# Altium schematic sheet RoBeast_Power_Linear.SchDoc(RoBeast_Power_Linear)
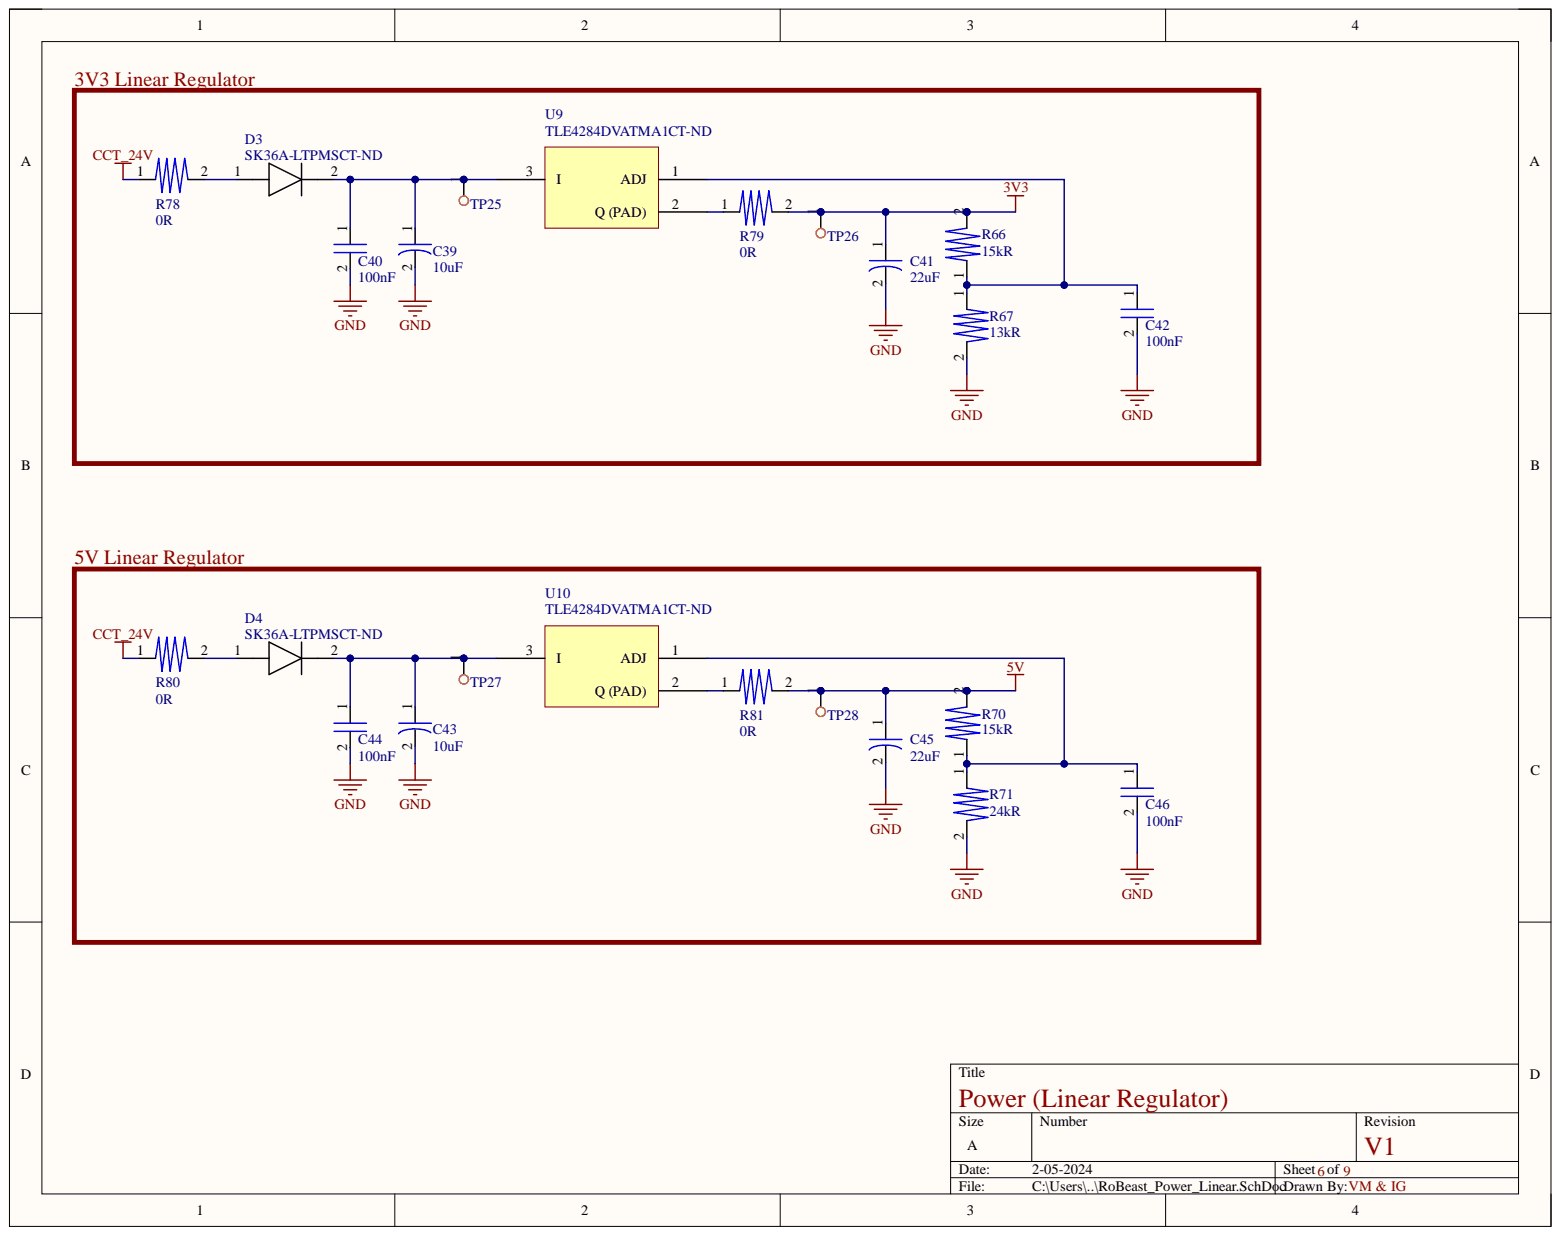
[diagram p0: RoBeast_Power_Linear.SchDoc]

## Parts
C39  10uF  [EXV106M050A9GAA]
C40  100nF  [0805]
C41  22uF  [EEV226M050A9GAA]
C42  100nF  [0805]
C43  10uF  [EXV106M050A9GAA]
C44  100nF  [0805]
C45  22uF  [EEV226M050A9GAA]
C46  100nF  [0805]
D3  Supplier Part Number 1: SK36A-LTPMSCT-ND  [SK36A-LTP]  (hidden comment: Shottky Diode)
D4  Supplier Part Number 1: SK36A-LTPMSCT-ND  [SK36A-LTP]  (hidden comment: Shottky Diode)
R66  15kR  [0805]
R67  13kR  [0805]
R70  15kR  [0805]
R71  24kR  [0805]
R78  0R  [1210]
R79  0R  [1210]
R80  0R  [1210]
R81  0R  [1210]
TP25  Test Point  [Test Point]  (value not drawn)
TP26  Test Point  [Test Point]  (value not drawn)
TP27  Test Point  [Test Point]  (value not drawn)
TP28  Test Point  [Test Point]  (value not drawn)
U9  Linear Regulator  Supplier Part Number 1: TLE4284DVATMA1CT-ND  [TLE4284DVATMA1]
U10  Linear Regulator  Supplier Part Number 1: TLE4284DVATMA1CT-ND  [TLE4284DVATMA1]

## Nets
3V3: C41.1 R66.2 R79.2 TP26.1
5V: C45.1 R70.2 R81.2 TP28.1
CCT_24V: R78.1 R80.1
GND: C39.2 C40.2 C41.2 C42.2 C43.2 C44.2 C45.2 C46.2 R67.2 R71.2
NetC39_1: C39.1 C40.1 D3.2 TP25.1 U9.3
NetC42_1: C42.1 R66.1 R67.1 U9.1
NetC43_1: C43.1 C44.1 D4.2 TP27.1 U10.3
NetC46_1: C46.1 R70.1 R71.1 U10.1
NetD3_1: D3.1 R78.2
NetD4_1: D4.1 R80.2
NetR79_1: R79.1 U9.2
NetR81_1: R81.1 U10.2

## Library data of the parts
C39 C43 = EXV106M050A9GAA: Aluminum Electrolytic Capacitor, Polarized, Aluminum (wet), 50V, 20% +Tol, 20% -Tol, 10uF, Surface Mount, 2626; Manufacturer: KEMET; Capacitor Type: Aluminium; ESR (Equivalent Series Resistance): 2.6R; Leakage Current: 5uA; Ripple Current: 98mA; Tan Delta: 0.12; Capacitance: 10uF; Category: Aluminum Electrolytic Capacitors; Component Kind: Standard; ComponentLink1Description: https://datasheet.ciiva.com:443/pdfs/VipMasterIC/IC/KEME/KEME-S-A0009591402/KEME-S-A0009591402-1.pdf?src-supplier=IHS+Markit; ComponentLink1URL: https://datasheet.ciiva.com:443/pdfs/VipMasterIC/IC/KEME/KEME-S-A0009591402/KEME-S-A0009591402-1.pdf?src-supplier=IHS+Markit; ComponentLink2Description: https://datasheet.ciiva.com/22698/1500293-22698078.pdf?src-supplier=Element14; ComponentLink2URL: https://datasheet.ciiva.com/22698/1500293-22698078.pdf?src-supplier=Element14; Dielectric Material: Aluminium; Height: 5.4mm; Length: 7.8mm; Manufacturer Part Number: EXV106M050A9GAA; Max Operating Temperature: 105°C; Min Operating Temperature: -55°C; Mount: Surface Mount; Negative Tolerance: 20%; Number of Pins: 2; Package Shape: Cylindrical; Package Style: SMTMeter; Packaging: Tape and Reel; Polarity: Polarized; Positive Tolerance: 20%; Pricing 1: 1=0.48, 10=0.32, 50=0.2546, 100=0.2102, 500=0.16422 (USD); Rated DC Voltage (URdc): 50V; Reach SVHC Compliant: Yes; RoHS: Yes; RoHS Compliant: Yes; Size Code: 2626; Stock 1: 4766; Supplier 1: Digikey; Supplier Part Number 1: 399-18153-1-ND; Termination: Flat; Width: 6.6mm; Library Name: RoBeast_Schematic_Library.SchLib
C40 C42 C44 C46 = C0805C104J5RAC7800: Ceramic Capacitor, Multilayer, Ceramic, 50V, 5% +Tol, 5% -Tol, X7R, 15% TC, 0.1uF, Surface Mount, 0805; Manufacturer: KEMET; Manufacturer Part Number: C0805C104J5RACTU; Multilayer: Yes; Capacitance: 100nF; Capacitor Type: Ceramic; Category: Ceramic Capacitors; Component Kind: Standard; ComponentLink1Description: http://capacitoredge.kemet.com:80/capedge2/intellispec.jsp?pn=C0805C104J5RACTU&displayPN=C0805C104J5RACTU&src-supplier=IHS+Markit; ComponentLink1URL: http://capacitoredge.kemet.com:80/capedge2/intellispec.jsp?pn=C0805C104J5RACTU&displayPN=C0805C104J5RACTU&src-supplier=IHS+Markit; ComponentLink2Description: https://datasheet.ciiva.com/7842/1732721-7842179.pdf?src-supplier=Element14; ComponentLink2URL: https://datasheet.ciiva.com/7842/1732721-7842179.pdf?src-supplier=Element14; ComponentLink3Description: https://datasheet.ciiva.com/28682/1851736-28682223.pdf?src-supplier=Element14; ComponentLink3URL: https://datasheet.ciiva.com/28682/1851736-28682223.pdf?src-supplier=Element14; ComponentLink4Description: https://datasheet.ciiva.com/7258/1828800-7258413.pdf?src-supplier=Newark; ComponentLink4URL: https://datasheet.ciiva.com/7258/1828800-7258413.pdf?src-supplier=Newark; ComponentLink5Description: https://datasheet.ciiva.com/14589/70095383-14589015.pdf?src-supplier=Allied+Electronics; ComponentLink5URL: https://datasheet.ciiva.com/14589/70095383-14589015.pdf?src-supplier=Allied+Electronics; ComponentLink6Description: https://datasheet.ciiva.com/5417/kem-c1002-x7r-smd-5417352.pdf?src-supplier=Mouser; ComponentLink6URL: https://datasheet.ciiva.com/5417/kem-c1002-x7r-smd-5417352.pdf?src-supplier=Mouser; ComponentLink7Description: https://datasheet.ciiva.com/39122/docfinderpartnumberc0402c102k5ractu-39122924.pdf?src-supplier=Onlinecomponents.com; ComponentLink7URL: https://datasheet.ciiva.com/39122/docfinderpartnumberc0402c102k5ractu-39122924.pdf?src-supplier=Onlinecomponents.com; Dielectric Material: Ceramic; JESD-609 Code: e3; Max Operating Temperature: 125°C; Min Operating Temperature: -55°C; Mount: Surface Mount; Negative Tolerance: 5%; Number of Pins: 2; Package Shape: Rectangular; Packaging: Tape and Reel; Positive Tolerance: 5%; Pricing 1: 1=0.18, 10=0.122, 50=0.0732, 100=0.0576, 500=0.04252, 1000=0.03519 (USD); Rated DC Voltage (URdc): 50V; Reach SVHC Compliant: Yes; RoHS: Yes; RoHS Compliant: Yes; Size Code: 0805; Stock 1: 605061; Supplier 1: Digikey; Supplier Part Number 1: 399-C0805C104J5RAC7800CT-ND; Temperature Characteristics Code: X7R; Terminal Finish: Nickel; Termination: Wraparound; Library Name: RoBeast_Schematic_Library.SchLib
C41 C45 = EEV226M050A9GAA: Aluminum Electrolytic Capacitor, Polarized, Aluminum (wet), 50V, 20% +Tol, 20% -Tol, 22uF, Surface Mount, 2626; Manufacturer: KEMET; Capacitor Type: Aluminium; Component Kind: Standard; ComponentLink1Description: https://datasheet.ciiva.com:443/pdfs/VipMasterIC/IC/KEME/KEME-S-A0008611149/KEME-S-A0008611149-1.pdf?src-supplier=IHS+Markit; ComponentLink1URL: https://datasheet.ciiva.com:443/pdfs/VipMasterIC/IC/KEME/KEME-S-A0008611149/KEME-S-A0008611149-1.pdf?src-supplier=IHS+Markit; ComponentLink2Description: https://api.kemet.com/component-edge/download/datasheet/EEV226M050A9GAA.pdf?src-supplier=Digikey; ComponentLink2URL: https://api.kemet.com/component-edge/download/datasheet/EEV226M050A9GAA.pdf?src-supplier=Digikey; Manufacturer Part Number: EEV226M050A9GAA; Number of Pins: 2; Pricing 1: 1=0.37, 10=0.243, 50=0.1788, 100=0.1457, 500=0.11498 (USD); Reach SVHC Compliant: Yes; RoHS: Yes; RoHS Compliant: Yes; Stock 1: 19791; Supplier 1: Digikey; Supplier Part Number 1: 399-18142-1-ND; Library Name: RoBeast_Schematic_Library.SchLib
D3 D4 = SK36A-LTP: Rectifier Diode, Schottky, 1 Phase, 1 Element, 3A, 60V V(RRM), Silicon, DO-214AC; Manufacturer: MCC; Application(s): GENERAL PURPOSE; Forward Voltage-Max (VF): 750mV; Non-rep Pk Forward Current-Max: 80A; Number of Elements: 1; Package Body Material: Plastic; Time @ Peak Reflow Temperature-Max (s): 10s; Category: Rectifier Diodes; Component Kind: Standard; ComponentLink1Description: https://datasheet.ciiva.com:443/pdfs/VipMasterIC/IC/MCCC/MCCC-S-A0007291529/MCCC-S-A0007291529-1.pdf?src-supplier=IHS+Markit; ComponentLink1URL: https://datasheet.ciiva.com:443/pdfs/VipMasterIC/IC/MCCC/MCCC-S-A0007291529/MCCC-S-A0007291529-1.pdf?src-supplier=IHS+Markit; ComponentLink2Description: https://datasheet.ciiva.com/17441/sk32a-ltsk310a-ltdo-214ac-17441980.pdf?src-supplier=Future+Electronics; ComponentLink2URL: https://datasheet.ciiva.com/17441/sk32a-ltsk310a-ltdo-214ac-17441980.pdf?src-supplier=Future+Electronics; ComponentLink3Description: https://datasheet.ciiva.com/917/sk32a-ltsk310a-lt28do-214ac29-917376.pdf?src-supplier=Digikey; ComponentLink3URL: https://datasheet.ciiva.com/917/sk32a-ltsk310a-lt28do-214ac29-917376.pdf?src-supplier=Digikey; ComponentLink4Description: https://datasheet.ciiva.com/917/sk32a-ltsk310a-lt28do-214ac29-917377.pdf?src-supplier=Digikey; ComponentLink4URL: https://datasheet.ciiva.com/917/sk32a-ltsk310a-lt28do-214ac29-917377.pdf?src-supplier=Digikey; ComponentLink5Description: https://datasheet.ciiva.com/917/sk32a-ltsk310a-lt28do-214ac29-917375.pdf?src-supplier=Digikey; ComponentLink5URL: https://datasheet.ciiva.com/917/sk32a-ltsk310a-lt28do-214ac29-917375.pdf?src-supplier=Digikey; Diode Element Material: SILICON; Diode Type: RECTIFIER; JEDEC-95 Code: DO-214AC; JESD-30 Code: R-PDSO-C2; JESD-609 Code: e3; Manufacturer Part Number: SK36A-LTP; Max Operating Temperature: 125°C; Max Output Current: 3A; Moisture Sensitivity Level: 1; Number of Phases: 1; Number of Pins: 2; Package Shape: Rectangular; Package Style: SMALL OUTLINEMeter; Peak Reflow Temperature (Cel): 260°C; Pricing 1: 1=0.34, 10=0.239, 100=0.1207, 500=0.09844, 1000=0.07304, 2000=0.06145 (USD); Reach SVHC Compliant: No; Rep Pk Reverse Voltage-Max: 60V; RoHS: Yes; RoHS Compliant: Yes; Stock 1: 225069; Supplier 1: Digikey; Surface Mount: Yes; Technology: SCHOTTKY; Terminal Finish: Tin; Terminal Form: C BEND; Terminal Position: DUAL; Library Name: RoBeast_Schematic_Library.SchLib
R66 R70 = SG73P2ATTD1502F: Fixed Resistor, Metal Glaze/thick Film, 0.5W, 15000ohm, 400V, 1% +/-Tol, 100ppm/Cel, Surface Mount, 0805; Manufacturer: KOA Speer; Package Height: 0.5mm; Package Length: 2mm; Package Width: 1.25mm; Rated Power Dissipation (P): 250mW; Rated Temperature: 70°C; Reference Standard: AEC-Q200; Temperature Coefficient: 100ppm/°C; Category: Resistors; Component Kind: Standard; ComponentLink1Description: https://datasheet.ciiva.com:443/pdfs/VipMasterIC/IC/KOAS/KOAS-S-A0009586268/KOAS-S-A0009586268-1.pdf?src-supplier=IHS+Markit; ComponentLink1URL: https://datasheet.ciiva.com:443/pdfs/VipMasterIC/IC/KOAS/KOAS-S-A0009586268/KOAS-S-A0009586268-1.pdf?src-supplier=IHS+Markit; ComponentLink2Description: https://datasheet.ciiva.com/5685/78338-5685838.pdf?src-supplier=Farnell; ComponentLink2URL: https://datasheet.ciiva.com/5685/78338-5685838.pdf?src-supplier=Farnell; ComponentLink3Description: https://datasheet.ciiva.com/22640/78338-22640859.pdf?src-supplier=Farnell; ComponentLink3URL: https://datasheet.ciiva.com/22640/78338-22640859.pdf?src-supplier=Farnell; ComponentLink4Description: https://datasheet.ciiva.com/7773/78338-7773512.pdf?src-supplier=Element14; ComponentLink4URL: https://datasheet.ciiva.com/7773/78338-7773512.pdf?src-supplier=Element14; Construction: Rectangular; JESD-609 Code: e3; Manufacturer Part Number: SG73P2ATTD1502F; Max Operating Temperature: 155°C; Min Operating Temperature: -55°C; Mount: Surface Mount; Number of Pins: 2; Package Style: SMTMeter; Packaging: Tape and Reel; Pricing 1: 1=0.18, 10=0.09, 50=0.0602, 100=0.0514, 500=0.03686, 1000=0.03245 (USD); Reach SVHC Compliant: Yes; Resistance: 15kR; Resistor Type: FIXED RESISTOR; RoHS: Yes; RoHS Compliant: Yes; Size Code: 0805; Stock 1: 5000; Supplier 1: Digikey; Supplier Part Number 1: 2019-SG73P2ATTD1502FCT-ND; Technology: METAL GLAZE/THICK FILM; Terminal Finish: Nickel; Termination: Wraparound; Tolerance: 1%; Working Voltage: 400V; Library Name: RoBeast_Schematic_Library.SchLib
R67 = RCS080513K0FKEA: Fixed Resistor, Metal Glaze/thick Film, 0.4W, 13000ohm, 150V, 1% +/-Tol, 100ppm/Cel, Surface Mount, 0805; Manufacturer: Vishay Dale; Category: Chip SMD Resistors; Component Kind: Standard; ComponentLink1Description: https://datasheet.ciiva.com:443/pdfs/VipMasterIC/IC/VISH/VISH-S-A0007978036/VISH-S-A0007978036-1.pdf?src-supplier=IHS+Markit; ComponentLink1URL: https://datasheet.ciiva.com:443/pdfs/VipMasterIC/IC/VISH/VISH-S-A0007978036/VISH-S-A0007978036-1.pdf?src-supplier=IHS+Markit; ComponentLink2Description: http://www.vishay.com/docs/20065/rcse3.pdf?src-supplier=Digikey; ComponentLink2URL: http://www.vishay.com/docs/20065/rcse3.pdf?src-supplier=Digikey; Manufacturer Part Number: RCS080513K0FKEA; Pricing 1: 1=0.2, 10=0.104, 50=0.0712, 100=0.0613, 500=0.045, 1000=0.03986 (USD); RoHS: Yes; Stock 1: 3462; Supplier 1: Digikey; Supplier Part Number 1: 541-RCS080513K0FKEACT-ND; Library Name: RoBeast_Schematic_Library.SchLib
R71 = RC0805FR-1324KL: Fixed Resistor, Metal Glaze/thick Film, 0.125W, 24000ohm, 150V, 1% +/-Tol, 100ppm/Cel, Surface Mount, 0805; Manufacturer: Yageo; Additional Feature: LASER TRIMMABLE; Package Height: 0.5mm; Package Length: 2mm; Package Width: 1.25mm; Power: 125mW; Rated Temperature: 70°C; Temperature Coefficient: 100ppm/°C; Case/Package: 0805; Category: Chip SMD Resistors; Component Kind: Standard; ComponentLink1Description: https://datasheet.ciiva.com:443/pdfs/VipMasterIC/IC/YAGO/YAGO-S-A0008145938/YAGO-S-A0008145938-1.pdf?src-supplier=IHS+Markit; ComponentLink1URL: https://datasheet.ciiva.com:443/pdfs/VipMasterIC/IC/YAGO/YAGO-S-A0008145938/YAGO-S-A0008145938-1.pdf?src-supplier=IHS+Markit; ComponentLink2Description: https://datasheet.ciiva.com/12575/rc0805-257173-12575893.pdf?src-supplier=Mouser; ComponentLink2URL: https://datasheet.ciiva.com/12575/rc0805-257173-12575893.pdf?src-supplier=Mouser; Construction: Chip; JESD-609 Code: e3; Manufacturer Part Number: RC0805FR-1324KL; Max Operating Temperature: 155°C; Min Operating Temperature: -55°C; Mounting Technology: Surface Mount; Package Shape: Rectangular; Package Style: SMTMeter; Packaging: Tape and Reel; Pins: 2; Pricing: 1=0.1, 10=0.025, 50=0.016, 100=0.0133, 500=0.00912, 1000=0.00787, 5000=0.00577, 10000=0.00511 (USD); Reach SVHC Compliant: Yes; Resistor Type: FIXED RESISTOR; RoHS: Yes; RoHS Compliant: Yes; Stock: 39739; Supplier: Digikey; Supplier Part Number: 13-RC0805FR-1324KLCT-ND; Technology: METAL GLAZE/THICK FILM; Terminal Finish: Nickel; Termination: Wraparound; Tolerance: 1%; Value: 24kR; Working Voltage: 150V; Library Name: RoBeast_Schematic_Library.SchLib
R78-R81 = RC1210JR-070RL: Metal Glaze/thick Film, 0.5W, 0ohm, Surface Mount, 1210; Manufacturer: Yageo; Package Height: 0.55mm; Package Length: 3.1mm; Package Width: 2.6mm; Rated Power Dissipation (P): 500mW; Rated Temperature: 70°C; Category: Chip SMD Resistors; Component Kind: Standard; ComponentLink1Description: https://datasheet.ciiva.com:443/pdfs/VipMasterIC/IC/YAGO/YAGO-S-A0008145938/YAGO-S-A0008145938-1.pdf?src-supplier=IHS+Markit; ComponentLink1URL: https://datasheet.ciiva.com:443/pdfs/VipMasterIC/IC/YAGO/YAGO-S-A0008145938/YAGO-S-A0008145938-1.pdf?src-supplier=IHS+Markit; ComponentLink2Description: https://datasheet.ciiva.com/11808/0900766b8079c86c-11808771.pdf?src-supplier=Rs; ComponentLink2URL: https://datasheet.ciiva.com/11808/0900766b8079c86c-11808771.pdf?src-supplier=Rs; ComponentLink3Description: https://datasheet.ciiva.com/5561/pyu-rc1210-51-rohs-l-4-5561862.pdf?src-supplier=Mouser; ComponentLink3URL: https://datasheet.ciiva.com/5561/pyu-rc1210-51-rohs-l-4-5561862.pdf?src-supplier=Mouser; Construction: Chip; JESD-609 Code: e3; Manufacturer Part Number: RC1210JR-070RL; Max Operating Temperature: 155°C; Min Operating Temperature: -55°C; Mount: Surface Mount; Number of Pins: 2; Package Shape: Rectangular; Package Style: SMTMeter; Packaging: Tape and Reel; Pricing 1: 1=0.14, 10=0.119, 25=0.0864, 50=0.0672, 100=0.0456, 250=0.03744, 500=0.02978, 1000=0.02113 (USD); Reach SVHC Compliant: Yes; Resistance: 0R; Resistor Type: FIXED RESISTOR; RoHS: Yes; RoHS Compliant: Yes; Size Code: 1210; Stock 1: 364141; Supplier 1: Digikey; Supplier Part Number 1: YAG3375CT-ND; Technology: METAL GLAZE/THICK FILM; Terminal Finish: Nickel; Termination: Wraparound; Library Name: RoBeast_Schematic_Library.SchLib
U9 U10 = TLE4284DVATMA1: Adjustable Positive LDO Regulator, 1.25V Min, 35V Max, 1.4V Dropout, BIPolar, PSSO3; Manufacturer: Infineon; Dropout Voltage1-Nom: 2.2V; Input-Output Voltage Differential-Max: 40V; Input-Output Voltage Differential-Min: 3V; Line Regulation-Max (%/V): 0.027; Load Regulation-Max(%): 1.5%; Number of Functions: 1; Output Current1-Max: 800mA; Package Body Material: Plastic; Package Equivalence Code: SMSIP3H,.38,90TB; Qualification Status: Not Qualified; Regulator Type: ADJUSTABLE POSITIVE SINGLE OUTPUT LDO REGULATOR; Adjustability: ADJUSTABLE; Category: Uncategorized; Component Kind: Standard; ComponentLink1Description: https://datasheet.ciiva.com:443/pdfs/VipMasterIC/IC/INFN/INFNS12905/INFNS12905-1.pdf?src-supplier=IHS+Markit; ComponentLink1URL: https://datasheet.ciiva.com:443/pdfs/VipMasterIC/IC/INFN/INFNS12905/INFNS12905-1.pdf?src-supplier=IHS+Markit; ComponentLink2Description: https://datasheet.ciiva.com/41439/001183750-da-01-en-ic-reg-ldo-adj-1a-tle4284dv-to-252-3-inf-41439516.pdf?src-supplier=Conrad; ComponentLink2URL: https://datasheet.ciiva.com/41439/001183750-da-01-en-ic-reg-ldo-adj-1a-tle4284dv-to-252-3-inf-41439516.pdf?src-supplier=Conrad; ComponentLink3Description: https://datasheet.ciiva.com/2049/infineon-tle4284-ds-v02-01-en-2049124.pdf?src-supplier=Digikey; ComponentLink3URL: https://datasheet.ciiva.com/2049/infineon-tle4284-ds-v02-01-en-2049124.pdf?src-supplier=Digikey; ComponentLink4Description: https://datasheet.ciiva.com/2049/infineon-tle4284-ds-v02-01-en-2049125.pdf?src-supplier=Digikey; ComponentLink4URL: https://datasheet.ciiva.com/2049/infineon-tle4284-ds-v02-01-en-2049125.pdf?src-supplier=Digikey; ComponentLink5Description: https://datasheet.ciiva.com/2049/infineon-tle4284-ds-v02-01-en-2049123.pdf?src-supplier=Digikey; ComponentLink5URL: https://datasheet.ciiva.com/2049/infineon-tle4284-ds-v02-01-en-2049123.pdf?src-supplier=Digikey; Dropout Voltage1-Max: 1.4V; Height - Seated (Max): 2.35mm; JESD-609 Code: e3; Length: 6.5mm; Manufacturer Part Number: TLE4284DV; Moisture Sensitivity Level: 1; Number of Outputs: 1; Number of Pins: 3; Operating Temperature, TJ-Max: 150°C; Operating Temperature, TJ-Min: -40°C; Output Voltage1-Max: 35V; Output Voltage1-Min: 1.25V; Package Code: TO-252; Package Shape: Rectangular; Package Style: SMALL OUTLINEMeter; Peak Reflow Temperature (Cel): 260°C; Pricing 1: 1=1.9, 10=1.706, 25=1.6096, 100=1.3712, 250=1.28756, 500=1.1266, 1000=0.93347 (USD); Reach SVHC Compliant: No; RoHS: Yes; RoHS Compliant: Yes; Stock 1: 11903; Supplier 1: Digikey; Surface Mount: Yes; Technology: BIPOLAR; Terminal Finish: Tin; Terminal Form: Gull Wing; Terminal Pitch: 2.28mm; Terminal Position: SINGLE; Width: 6.22mm; Library Name: RoBeast_Schematic_Library.SchLib
TP25-TP28 = Test Point: Component Kind: Standard; Library Name: RoBeast_Schematic_Library.SchLib
